# Altium schematic sheet [02]_PWR.SchDoc([02]_PWR)
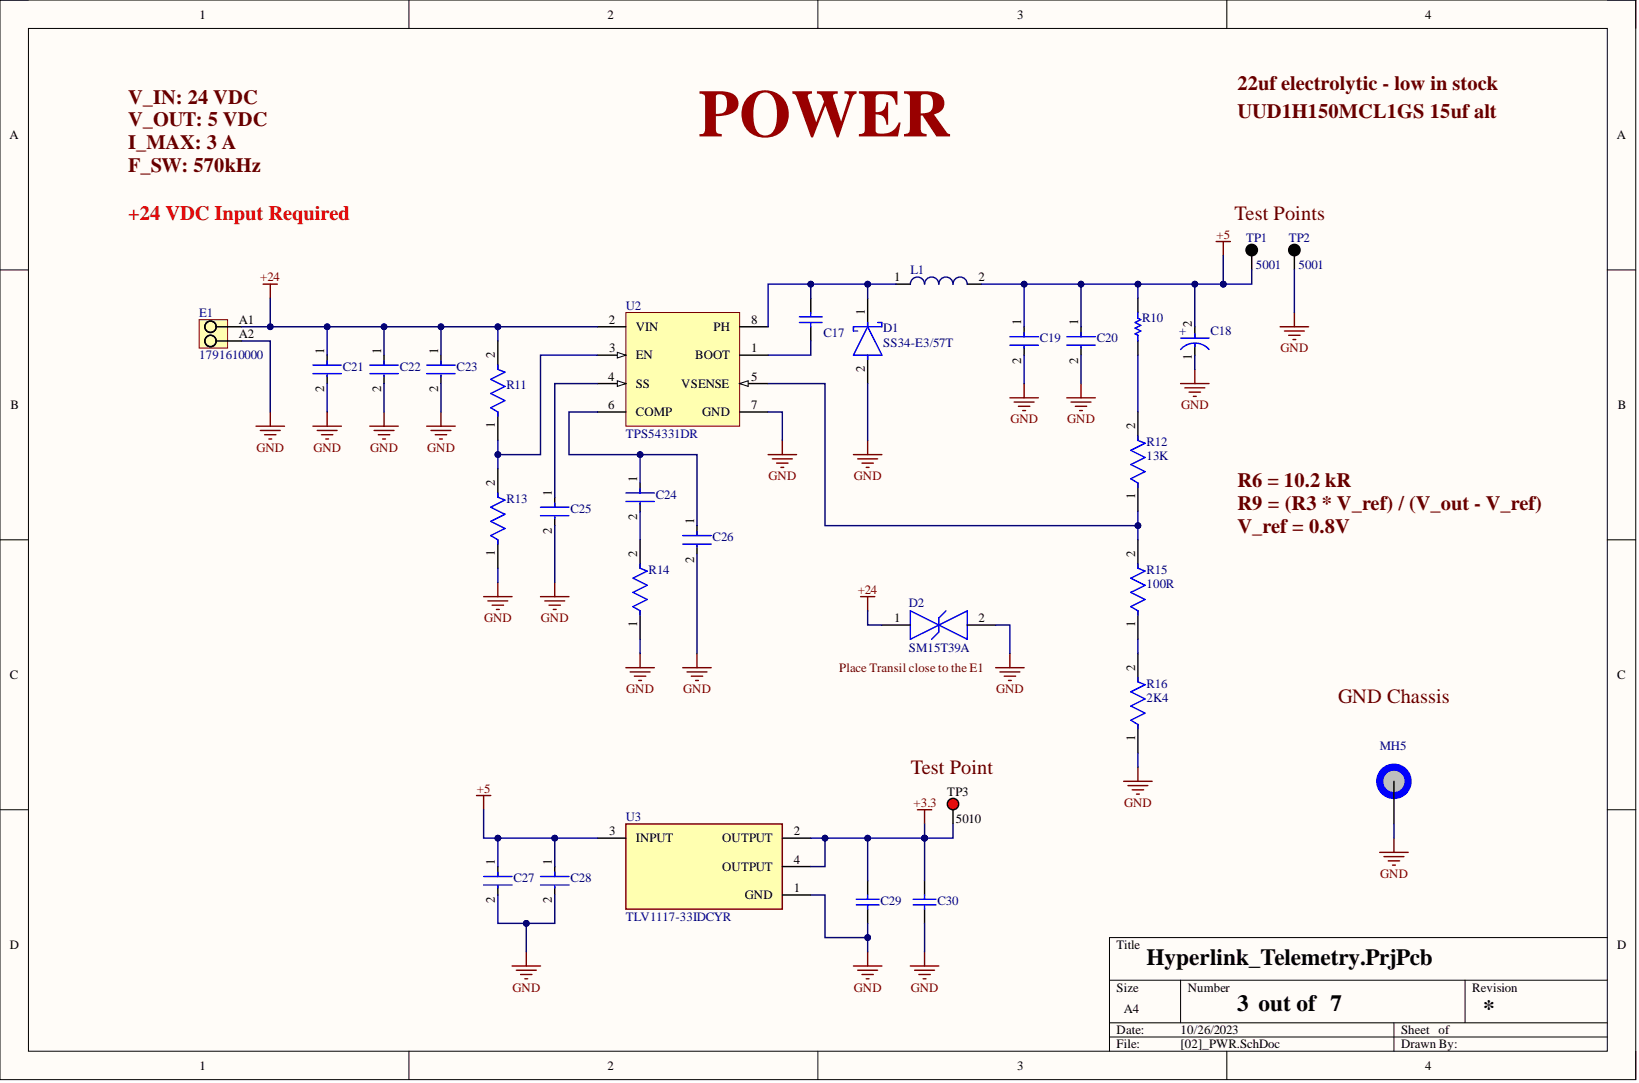
[diagram p0: [02]_PWR.SchDoc]

## Parts
C17  GRM188R71H104KA93D  (value not drawn)
C18  EEEFK1V220R  (value not drawn)
C19  GRM188R60J476ME15D  (value not drawn)
C20  GRM188R60J476ME15D  (value not drawn)
C21  TMK107BBJ475KA-T  (value not drawn)
C22  TMK107BBJ475KA-T  (value not drawn)
C23  CC0603KRX7R9BB103  (value not drawn)
C24  CC0603KRX7R9BB103  (value not drawn)
C25  CC0603KRX7R9BB103  (value not drawn)
C26  CL10C470JC8NNNC  (value not drawn)
C27  C3216X5R1E476M160AC  (value not drawn)
C28  C3216X5R1E476M160AC  (value not drawn)
C29  GRM188R71H104KA93D  (value not drawn)
C30  GRM188R71H104KA93D  (value not drawn)
D1  SS34-E3/57T
D2  SM15T39A
E1  1791610000
L1  74404064068  (value not drawn)
MH5  MH_7.4MMC_3.2MMD  (value not drawn)  (no BOM)
R10  CRCW06030000Z0EA  (value not drawn)
R11  AC0603FR-07332KL  (value not drawn)
R12  13K
R13  CRCW060368K0FKEA  (value not drawn)
R14  (no value)
R15  100R
R16  2K4
TP1  5001
TP2  5001
TP3  5010
U2  TPS54331DR
U3  TLV1117-33IDCYR

## Nets
+3.3: C29.2 C30.2 TP3.1 U3.2(OUTPUT) U3.4(OUTPUT)
+5: C18.2 C19.1 C20.1 C27.1 C28.1 L1.2 R10.2 TP1.1 U3.3(INPUT)
+24: C21.1 C22.1 C23.1 D2.1 E1.A1 R11.2 U2.2(VIN)
GND: C18.1 C19.2 C20.2 C21.2 C22.2 C23.2 C25.2 C26.2 C27.2 C28.2 C29.1 C30.1 D1.2 D2.2 E1.A2 MH5.1 R13.1 R14.1 R16.1 TP2.1 U2.7(GND) U3.1(GND)
NetC17_1: C17.1 U2.1(BOOT)
NetC17_2: C17.2 D1.1 L1.1 U2.8(PH)
NetC24_1: C24.1 C26.1 U2.6(COMP)
NetC24_2: C24.2 R14.2
NetC25_1: C25.1 U2.4(SS)
NetR10_1: R10.1 R12.2
NetR11_1: R11.1 R13.2 U2.3(EN)
NetR12_1: R12.1 R15.2 U2.5(VSENSE)
NetR15_1: R15.1 R16.2

## Library data of the parts
C17 C29 C30 = CMP-2100-03643-1: Chip Capacitor, 0.1 uF, +/- 10%, 50 V, -55 to 125 degC, 0603 (1608 Metric), RoHS, Tape and Reel; Manufacturer: Murata; Capacitance: 100nF; Dielectric: X7R; Dielectric Material: Ceramic; Insulation Resistance: 10GR; Voltage: 50V; Case Code (Imperial): 0603; Case Code (Metric): 1608; Case/Package: 0603; Component Kind: Standard; Contact Plating: Tin; Datasheet URL: http://psearch.en.murata.com/capacitor/product/GRM188R71H104KA93%23.pdf; Datasheet Version: 42675.0; Depth: 0.8mm; Height: 0.8mm; JLC: C14663; Lead Free: LeadFree; Length: 1.6mm; Library Name: Altium Content Vault; Manufacturer URL: http://www.murata.com/; Material: Ceramic; Max Operating Temperature: 125degC; Min Operating Temperature: -55degC; Mount: SurfaceMount; Number of Pins: 2; Package Description: 2-Pin Surface Mount Device, Body 1.6 x 0.8 mm; Package Quantity: 1; Package Reference: 0603; Packaging: TapeandReel; Radiation Hardening: No; REACH SVHC: NoSVHC; RoHSCompliant: true; Termination: SMD/SMT; Thickness: 0.035inch; Tolerance: 10%; Voltage Rating: 50V; Voltage Rating (DC): 50V; Width: 0.8mm
C18 = CMP-05427-000063-1: CAP ALUM 22UF 20% 35V SMD; Dielectric Material: Aluminium; ESR (Equivalent Series Resistance): 700mR; Leakage Current: 3uA; Life (Hours): 2000Hour; Ripple Current: 160mA; Capacitance: 22uF; Case/Package: Radial; Component Kind: Standard; Construction: Cylindrical; Contact Plating: Tin; Diameter: 5mm; Height: 5.8mm; Height - Seated (Max): 0.228inch; Impedance: 700mR; JLC: C249982; Lead Free: Lead Free; Length: 5.8mm; Library Name: Altium Content Vault; Material: Aluminium; Max Operating Temperature: 105°C; Min Operating Temperature: -55°C; Mount: Surface Mount; Number of Pins: 2; Package Quantity: 1; Packaging: Tape and Reel; Radiation Hardening: No; REACH SVHC: No SVHC; Ripple Current (AC): 160mA; RoHS Compliant: Yes; Series: FK; Termination: SMD/SMT; Tolerance: 20%; Voltage: 35V; Voltage Rating: 35V; Voltage Rating (DC): 35V; Width: 6.5mm
C19 C20 = CMP-2000-05444-2: Chip Multilayer Ceramic Capacitors for General Purpose, 0603, 47uF, X5R, 15%, 20%, 6.3V; Capacitance: 47uF; Case Code (Imperial): 0603; Case Code (Metric): 1608; Case/Package: 0603; Component Kind: Standard; Dielectric: X5R; JLC: C1623; Length: 0.063inch; Library Name: Altium Content Vault; Max Operating Temperature: 85°C; Min Operating Temperature: -55°C; Mount: Surface Mount; Packaging: Tape and Reel; Thickness: 0.039inch; Tolerance: 20%; Voltage Rating: 6.3V; Voltage Rating (DC): 6.3V; Width: 0.031inch
C21 C22 = CMP-14477-004926-2: Multilayer Ceramic Capacitor 4.7uF 25V X5R 10% SMD 0603 T/R; Capacitance: 4.7uF; Case Code (Imperial): 0603; Case Code (Metric): 1608; Case/Package: 0603; Component Kind: Standard; Contact Plating: Tin; Dielectric: X5R; JLC: C19666; Lead Free: Lead Free; Length: 0.063inch; Library Name: Altium Content Vault; Max Operating Temperature: 85°C; Min Operating Temperature: -55°C; Mount: Surface Mount; Packaging: Tape and Reel; RoHS Compliant: Yes; Termination: Wraparound; Thickness: 0.039inch; Tolerance: 10%; Voltage Rating: 25V; Width: 0.031inch
C23-C25 = CMP-2100-03600-2: CAP CER 10000PF 50V X7R 0603; Manufacturer: YAGEO; Capacitance: 10 nF; Material: Ceramic; Temperature Coefficient: 15%; Tolerance: 0.1; Value: 10 nF; Automotive: No; Category: Cap; Component Kind: Standard; ComponentLink2Description: Datasheet; ComponentLink2URL: http://www.yageo.com/documents/recent/UPY-GPHC_X7R_6.3V-to-50V_18.pdf; Device Class L1: Passive Components; Device Class L2: Capacitors; Device Class L3: Ceramic Capacitors; Digikey Description: CAP CER 10000PF 50V X7R 0603; Footprint Url: http://www.yageo.com/exep/pages/download/literatures/UPY-C_GEN_24.pdf; Height: 0.9mm; JLC: C57112; Lead Free: Yes; Library Name: Altium Content Vault; Manufacturer Part Number: CC0603KRX7R9BB103; Mouser Description: Multilayer Ceramic Capacitor, CC Series, 0.01 - F, - 10%, X7R, 50 V, 0603 [1608 Metric]; Octopart: https://octopart.com/cc0603krx7r9bb103-yageo-192938; Package: CC0603-DA; RoHS: TRUE; Temperature: -55°C to +125°C; Temperature Characteristic: X7R; Temperature Range High: +125°C; Temperature Range Low: -55°C; Voltage: 50 V; Voltage Rating: 50 V
C26 = CMP-13271-001416-1: Cap Ceramic 47pF 100V C0G ±5% Pad SMD 0603 +125°C T/R; Capacitance: 47pF; Case Code (Imperial): 0603; Case Code (Metric): 1608; Case/Package: 0603; Component Kind: Standard; Depth: 0.8mm; Dielectric: C0G; Height: 0.8mm; JLC: C1671; Length: 1.6mm; Library Name: Altium Content Vault; Material: Ceramic; Max Operating Temperature: 125°C; Min Operating Temperature: -55°C; Mount: Surface Mount; Packaging: Tape and Reel; Radiation Hardening: No; Thickness: 0.035inch; Tolerance: 5%; Voltage Rating: 100V; Voltage Rating (DC): 100V; Width: 0.031inch
C27 C28 = CMP-2000-05397-2: CAP CER 47UF 25V X5R 1206; 3DS Part Number: 220-00004; Manufacturer: TDK; Material: Ceramic; Temperature Coefficient: 15%; Tolerance: 20%; Automotive: No; Capacitance: 47uF; Category: Cap; Component Kind: Standard; ComponentLink2Description: Datasheet; ComponentLink2URL: https://product.tdk.com/info/en/catalog/datasheets/mlcc_commercial_general_en.pdf; Device Class L1: Passive Components; Device Class L2: Capacitors; Device Class L3: Ceramic Capacitors; Digikey Description: CAP CER 47UF 25V X5R 1206; Footprint Url: https://product.tdk.com/info/en/catalog/spec/mlccspec_commercial_general_midvoltage_en.pdf; Height: 1.8mm; Ipc Land Pattern Name: CAPC320160X160; JLC: C96123; Lead Free: Yes; Library Name: Altium Content Vault; Manufacturer Part Number: C3216X5R1E476M160AC; Mouser Description: Multilayer Ceramic Capacitors MLCC - SMD/SMT 1206 47uF 25volts X5R 20%; Octopart: https://octopart.com/c3216x5r1e476m160ac-tdk-25947783; Package: C3216-160; RoHS: TRUE; Temperature: -55°C to +85°C; Temperature Characteristic: X5R; Temperature Range High: +85°C; Temperature Range Low: -55°C; Value: 47uF; Voltage: 25V; Voltage Rating: 25V
D1 = CMP-02539-000012-1: DIODE SCHOTTKY 40V 3A DO214AB; Manufacturer: Vishay; Max Junction Temp: +150°C; Max Surge Forward Current: 100A; Peak Reverse Current: 0.5mA; Standoff Height: 0mm; Automotive: No; Category: Diode; Component Kind: Standard; ComponentLink2Description: Datasheet; ComponentLink2URL: http://www.vishay.com/docs/88751/ss32.pdf; Device Class L1: Discrete Semiconductors; Device Class L2: Diodes; Device Class L3: Schottky Diodes; Digikey Description: DIODE SCHOTTKY 40V 3A DO214AB; Footprint Url: http://www.vishay.com/docs/95402/smc.pdf; Forward Current: 3A; Height: 2.62mm; JLC: C15570; Lead Free: Yes; Library Name: Altium Content Vault; Manufacturer Part Number: SS34-E3/57T; Max Repetitive Reverse Voltage: 40V; Mouser Description: Schottky Diodes & Rectifiers 3.0 Amp 40 Volt; Octopart: https://octopart.com/ss34-e3%2F57t-vishay-39552907; Package: 95402; RoHS: TRUE; Temperature: -55°C to +150°C; Temperature Range High: +150°C; Temperature Range Low: -55°C
D2 = CMP-12225-000046-1: TVS DIODE 39V; Manufacturer: STMicroelectronics; Manufacturer Part Number: SM15T33CAY; Automotive Grade: Grade 0; Breakdown Voltage: 31.4V; Clamping Voltage: 45.7V; Direction: Bidirectional; Max Junction Temp: +150°C; Number Of Channels: 1; Peak Pulse Current: 33A; Peak Pulse Power: 1500W; Reverse Standoff Voltage: 28.2V; Standoff Height: 0.05mm; Automotive: Yes; Category: Diode; Component Kind: Standard; ComponentLink2Description: Datasheet; ComponentLink2URL: https://www.st.com/resource/en/datasheet/sm15ty.pdf; Device Class L1: Circuit Protection; Device Class L2: TVS Diodes; Device Class L3: unset; Digikey Description: TVS DIODE 28.2V 59V SMC; Height: 2.65mm; JLC: C1976564; Lead Free: Yes; Library Name: Altium Content Vault; Mouser Description: TVS Diodes - Transient Voltage Suppressors 1500 W 10kW Transil 5.8V tp 70V BI; Octopart: https://octopart.com/sm15t33cay-stmicroelectronics-19039450; Package: SMC-CA; RoHS: TRUE; Temperature: -40°C to +150°C; Temperature Range High: +150°C; Temperature Range Low: -40°C
E1 = CMP-1559-01622-1: OMNIMATE Signal - series PM; Manufacturer: Weidmueller; Contact_material: Cu-Leg; Contact_surface: tinned; Insulating_material: Wemid (PA); Mounting_onto_the_PCB: Solder connection; No_of_poles: 2; Pitch_inch: 0,197 inch; Pitch_mm: 5 mm; Rated_current_use_group_B_UL_1059: 15 A; Rated_voltage_for_surge_voltage_class_pollution_degree_II_2: 600 V; Rated_voltage_use_group_B_UL_1059: 300 V; Short_text_for_material: PM 5.00/02/90 3.5SN OR BX; Side_termination_characteristic: closed side; Solder_pin_length_mm: 3,5 mm; Solid_max_H05_07_V_U: 2,5 mm²; Solid_min_H05_07_V_U: 0,13 mm²; UL_94_flammability_rating: V-0; Wire_cross_section_AWG_max_UL_1059: AWG 14; Wire_cross_section_AWG_min_UL_1059: AWG 26; Colour: Orange; Component Kind: Standard; ComponentLink1Description: Manufacturer URL; ComponentLink1URL: http://www.weidmueller.com/; ComponentLink2Description: URL Link1; ComponentLink2URL: http://catalog.weidmueller.com/catalog/Start.do?localeId=en&ObjectID=1791610000; JLC: C480516; Library Name: Altium Content Vault; PackageReference: PM_5_00_90_02; Packaging: Box; PartNumber: 1791610000; Qty: 500 PCS; Revision: V1
L1 = CMP-1354-00076-1: WE-LQS SMD Power Inductor, L=6.8 µH; Manufacturer: Wuerth Elektronik; Inductance: 6.8 ÂµH; Component Kind: Standard; ComponentLink1Description: Manufacturer URL; ComponentLink1URL: http://www.we-online.com; ComponentLink2Description: Datasheet; ComponentLink2URL: http://katalog.we-online.de/pbs/datasheet/74404064068.pdf; JLC: C42261; Library Name: Altium Content Vault; PackageReference: WE-LQS 6045; PartNumber: 74404064068
MH5 = MH_7.4MMC_3.2MMD: Mounting Hole 7.4mm Diameter, 3.2mm Drill; Library Name: Hyperlink_Int_Library.IntLib
R10 = CMP-2000-03816-5: Chip Resistor, 0 Ohm, Jumper, 100 mW, -55 to 155 degC, 0603 (1608 Metric), RoHS, Tape and Reel; Manufacturer: Vishay Semiconductor; Voltage Rating: 75 V; Component Kind: Standard; ComponentLink1Description: Manufacturer URL; ComponentLink1URL: http://www.vishay.com/; ComponentLink2Description: Datasheet; ComponentLink2URL: http://www.vishay.com/docs/20035/dcrcwe3.pdf; DatasheetVersion: 06/2012; Height: 0.45 mm; JLC: C21189; Length: 1.55 mm; Library Name: Altium Content Vault; Max Operating Temperature: 155 degC; Min Operating Temperature: -55 degC; Mount: Surface Mount; Mounting Technology: Surface Mount; Package Quantity: 5000; PackageDescription: 2-Pin Surface Mount Device, Body 1.575 x 0.85 mm; PackageReference: 0603; Packaging: Tape and Reel; Pins: 2; REACH SVHC: Unknown; RoHSCompliant: true
R11 = CMP-03412-017394-1: RES SMD 332K OHM 1% 1/10W 0603; Manufacturer: Yageo; Automotive Grade: Grade 0; Power Rating: 0.1W; Resistance: 332KΩ; Tolerance: 0.01; Value: 332KΩ; Voltage Rating: 75V; Automotive: Yes; Category: Res; Component Kind: Standard; ComponentLink2Description: Datasheet; ComponentLink2URL: http://www.yageo.com/NewPortal/yageodocoutput?fileName=/pdf/R-Chip/PYu-AC_51_RoHS_L_6.pdf; Composition: Thick Film; Device Class L1: Passive Components; Device Class L2: Resistors; Device Class L3: Chip SMD Resistors; Digikey Description: RES SMD 332K OHM 1% 1/10W 0603; Footprint Url: http://www.yageo.com/exep/pages/download/literatures/PYu-R_Mount_9.pdf; Height: 0.55mm; Ipc Land Pattern Name: RESC16080X45; JLC: C23137; Lead Free: Yes; Library Name: Altium Content Vault; Manufacturer Part Number: AC0603FR-07332KL; Mouser Description: Thick Film Resistors - SMD 1/10W 332K ohm 1% AEC-Q200; Octopart: https://octopart.com/ac0603fr-07332kl-yageo-30510765; Package: AC0603; RoHS: TRUE; Series: AC; Temperature: -55°C to +155°C; Temperature Range High: +155°C; Temperature Range Low: -55°C
R12 = CMP-2000-03840-2: RES Thick Film, 13kΩ, 1%, 0.1W, 100ppm/°C, 0603; Max Power Dissipation: 100mW; Resistance: 13kR; Voltage Rating: 75V; Case Code (Imperial): 0603; Case Code (Metric): 1608; Case/Package: 0603; Component Kind: Standard; Composition: Thick Film; Contact Plating: Tin; Depth: 0.85mm; Features: Automotive AEC-Q200; Height: 0.45mm; JLC: C22797; Lead Free: Lead Free; Length: 1.55mm; Library Name: Altium Content Vault; Max Operating Temperature: 155°C; Military Standard: Not; Min Operating Temperature: -55°C; Number of Terminations: 2; Package Quantity: 10; Packaging: Tape and Reel; Power Rating: 100mW; Radiation Hardening: No; Temperature Coefficient: 100ppm/°C; Termination: SMD/SMT; Tolerance: 1%; Voltage Rating (DC): 75V; Weight: 7.1E-05oz; Width: 0.033inch
R13 = CMP-1012-00717-3: RES Thick Film, 68kΩ, 1%, 0.1W, 100ppm/°C, 0603; Max Power Dissipation: 100mW; Resistance: 68kR; Voltage Rating: 75V; Case Code (Imperial): 0603; Case Code (Metric): 1608; Case/Package: 0603; Component Kind: Standard; Composition: Thick Film; Contact Plating: Tin; Depth: 0.85mm; Features: Automotive AEC-Q200; Height: 0.45mm; JLC: C23231; Lead Free: Lead Free; Length: 1.55mm; Library Name: Altium Content Vault; Max Operating Temperature: 155°C; Military Standard: Not; Min Operating Temperature: -55°C; Mount: Surface Mount; Number of Pins: 2; Number of Terminations: 2; Package Quantity: 10; Packaging: Tape and Reel; Power Rating: 100mW; Radiation Hardening: No; Temperature Coefficient: 100ppm/°C; Termination: SMD/SMT; Tolerance: 1%; Voltage Rating (DC): 75V; Weight: 7.1E-05oz; Width: 0.033inch
R14 = CMP-1012-00717-3: RES Thick Film, 30kΩ, 1%, 0.1W, 100ppm/°C, 0603; Max Power Dissipation: 100mW; Resistance: 30kR; Voltage Rating: 75V; Case Code (Imperial): 0603; Case Code (Metric): 1608; Case/Package: 0603; Component Kind: Standard; Composition: Thick Film; Contact Plating: Tin; Depth: 0.85mm; Features: Automotive AEC-Q200; Height: 0.45mm; JLC: C22984; Lead Free: Lead Free; Length: 1.55mm; Library Name: Altium Content Vault; Max Operating Temperature: 155°C; Military Standard: Not; Min Operating Temperature: -55°C; Mount: Surface Mount; Number of Pins: 2; Number of Terminations: 2; Package Quantity: 10; Packaging: Tape and Reel; Power Rating: 100mW; Radiation Hardening: No; Temperature Coefficient: 100ppm/°C; Termination: SMD/SMT; Tolerance: 1%; Voltage Rating (DC): 75V; Weight: 7.1E-05oz; Width: 0.033inch
R15 = CMP-2000-03163-2: RES Thick Film, 100Ω, 5%, 0.1W, 200ppm/°C, 0603; Max Power Dissipation: 100mW; Voltage Rating: 75V; Case Code (Imperial): 0603; Case Code (Metric): 1608; Case/Package: 0603; Component Kind: Standard; Composition: Thick Film; Contact Plating: Tin; Depth: 0.85mm; Features: Automotive AEC-Q200; Height: 0.45mm; JLC: C22775; Lead Free: Lead Free; Length: 1.55mm; Library Name: Altium Content Vault; Max Operating Temperature: 155°C; Military Standard: Not; Min Operating Temperature: -55°C; Mount: Surface Mount; Number of Pins: 2; Number of Terminations: 2; Package Quantity: 1; Packaging: Tape and Reel; Power Rating: 100mW; Radiation Hardening: No; Resistance: 100R; Temperature Coefficient: 200ppm/°C; Termination: SMD/SMT; Tolerance: 5%; Voltage Rating (DC): 75V; Weight: 7.1E-05oz; Width: 0.033inch; Working Voltage: 75V
R16 = CMP-2000-03163-2: RES Thick Film, 2.4kΩ, 5%, 0.1W, 200ppm/°C, 0603; Max Power Dissipation: 100mW; Resistance: 2.4kR; Voltage Rating: 75V; Case Code (Imperial): 0603; Case Code (Metric): 1608; Case/Package: 0603; Component Kind: Standard; Composition: Thick Film; Contact Plating: Tin; Depth: 0.85mm; Features: Automotive AEC-Q200; Height: 0.45mm; JLC: C22940; Lead Free: Lead Free; Length: 1.55mm; Library Name: Altium Content Vault; Max Operating Temperature: 155°C; Military Standard: Not; Min Operating Temperature: -55°C; Mount: Surface Mount; Number of Pins: 2; Number of Terminations: 2; Package Quantity: 1; Packaging: Tape and Reel; Power Rating: 100mW; Radiation Hardening: No; Temperature Coefficient: 200ppm/°C; Termination: SMD/SMT; Tolerance: 5%; Voltage Rating (DC): 75V; Weight: 7.1E-05oz; Width: 0.033inch; Working Voltage: 75V
TP1 TP2 = CMP-2000-05181-1: Test Point, Black, 1-Pin THD, RoHS, Bulk; Manufacturer: Keystone; Alternate Color: 5004K-ND  -TESTPOINTPCMINI.040"DYELLOW  5003K-ND  -TESTPOINTPCMINI.040"DORANGE  5002K-ND  -TESTPOINTPCMINI.040"DWHITE  5000K-ND  -TESTPOINTPCMINI.040"DRED; Catalog Drawings: 5000Series; Kits: DKTGRS232C-KIT-ND  -TTLCONVERTER; Online Catalog: ColorCodedTestPoints; Other Names: 5001K; Category: TestandMeasurement; Color: Black; Component Kind: Standard; Datasheet URL: https://ciiva.com/datasheet-click-2917627?src=ciiva&sig=bd195616; Datasheet Version: Rev. G, 12/2008; Family: TestPoints; JLC: C238126; Library Name: Altium Content Vault; Manufacturer URL: http://www.keyelco.com/; Material: Base,Nylon46,UL94V-0; Mounting Type: 0.040"(1.02mm)HoleDiameter; Packaging: Bulk; RoHS Information: RoHSComplianceStatement; Series: THM; Standard Package: 100; Terminal: 0.010"x0.020"(0.25mmx0.51mm)PhosphorBronzeWire,SilverPlated; Type: PCTestPoint,Miniature
TP3 = CMP-1672-00002-4: Test Point, Red, Through Hole, RoHS, Bulk; Manufacturer: Keystone; Colour: Red; Component Kind: Standard; ComponentLink1Description: Manufacturer URL; ComponentLink1URL: http://www.keyelco.com/; ComponentLink2Description: Datasheet; ComponentLink2URL: http://www.keyelco.com/product-pdf.cfm?p=1319; Contact Material: Bronze; Contact Plating: Silver; DatasheetVersion: Rev. F, 12/2013; Height: 8.12 mm; JLC: C238126; Library Name: Altium Content Vault; Mount: Through Hole; Mounting Technology: Through Hole; Package Quantity: 100; PackageDescription: 1-Pin Through Hole Device, Dia 3.17 mm; PackageReference: 5010; Packaging: Bulk; Pins: 1; REACH SVHC: No SVHC; RoHSCompliant: true
U2 = CMP-0323-00209-3: Buck Step Down Regulator with 3.5 to 28 V Input and 0.8 to 25 V Output, -40 to 150 degC, 8-Pin SOIC (D), Green (RoHS & no Sb/Br); Manufacturer: Texas Instruments; Duty Cycle(Max)(%): 90; Iout(Max)(A): 3; Iq(Typ)(mA): 0.11; Rating: Catalog; Regulated Outputs(#): 1; SubFamily: Step-Down Regulator; Switch Current Limit(Typ)(A): 3.5; Vin(Max)(V): 28; Vout(Max)(V): 25; Vout(Min)(V): 0.8; Code_JEDEC: MS-012AA; Component Kind: Standard; ComponentLink1Description: Datasheet; ComponentLink1URL: http://www.ti.com/general/docs/lit/getliterature.tsp?genericPartNumber=TPS54331&fileType=pdf; ComponentLink2Description: Package Specification; ComponentLink2URL: http://www.ti.com/litv/pdf/msoi002j; ComponentLink3Description: URL Link1; ComponentLink3URL: Samples URL:http://www.ti.com/product/tps54331#samples; DatasheetVersion: SLVS839D; Eco-Plan: Green (RoHS & no Sb/Br); JLC: C9865; Library Name: Altium Content Vault; Mounting Technology: Surface Mount; PackageDescription: 8-Pin Plastic Small Outline Integrated Circuit, 4.9 x 3.9 mm, Pitch 1.27 mm Pitch; PackageReference: D0008A; PackageVersion: revM, Jun-2011; PartNumber: TPS54331DR; Topology: Buck; Vin(Min)(V): 3.5
U3 = CMP-04917-000056-1: IC REG LIN 3.3V 800MA SOT223-4; Manufacturer: Texas Instruments; Dropout Voltage: 1.2V; Max Junction Temp: +125°C; Max Supply Voltage: 15V; Min Supply Voltage: 4.7V; Nominal Supply Current: 5mA; Number Of Outputs: 1; Output Current: 0.8A; Output Type: Fixed; Standoff Height: 0.02mm; Automotive: No; Category: IC; Component Kind: Standard; ComponentLink2Description: Datasheet; ComponentLink2URL: http://www.ti.com/lit/ds/symlink/tlv1117.pdf; Device Class L1: Integrated Circuits (ICs); Device Class L2: Power Management ICs; Device Class L3: Voltage Regulators - Linear; Digikey Description: IC REG LIN 3.3V 800MA SOT223-4; Height: 1.8mm; JLC: C37359; Lead Free: Yes; Library Name: Altium Content Vault; Manufacturer Part Number: TLV1117-33IDCYR; Mouser Description: LDO Voltage Regulators Fixed LDO Volt Reg; Octopart: https://octopart.com/tlv1117-33idcyr-texas+instruments-669250; Output Voltage: 3.3V; Package: DCY0004A; RoHS: TRUE; Temperature: -40°C to +125°C; Temperature Range High: +125°C; Temperature Range Low: -40°C
